# Altium schematic sheet OneKey_Download.SchDoc(OneKey_Download)
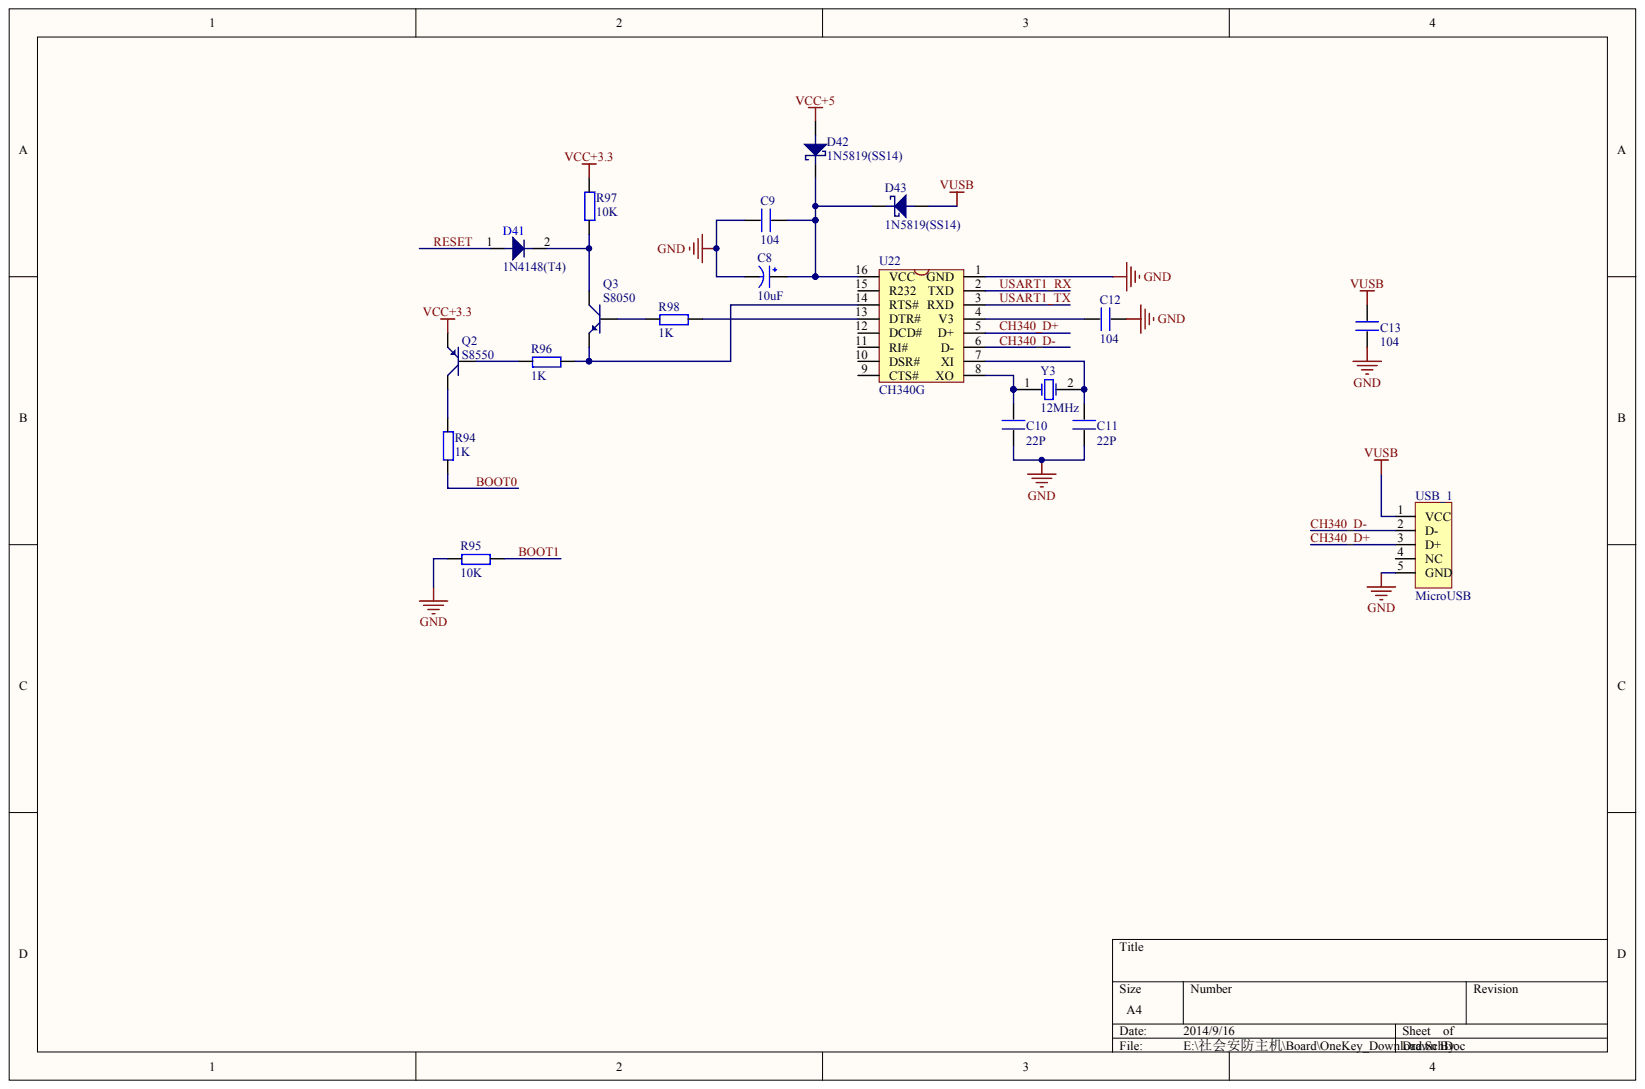
[diagram p0: OneKey_Download.SchDoc]

## Parts
C8  10uF
C9  104
C10  22P
C11  22P
C12  104
C13  104
D41  1N4148(T4)
D42  1N5819(SS14)
D43  1N5819(SS14)
Q2  S8550
Q3  S8050
R94  1K
R95  10K
R96  1K
R97  10K
R98  1K
U22  CH340G
USB_1  MicroUSB
Y3  12MHz

## Nets
GND: C8.2 C9.1 C10.1 C11.1 C12.2 C13.1 R95.1 U22.1(GND) USB_1.5(GND)
VCC+3.3: Q2.1 R97.2
VCC+5: D42.1
VUSB: C13.2 D43.1 USB_1.1(VCC)
BOOT0: R94.1
BOOT1: R95.2
CH340_D+: U22.5(D+) USB_1.3(D+)
CH340_D-: U22.6(D-) USB_1.2(D-)
RESET: D41.1
USART1_RX: U22.2(TXD)
USART1_TX: U22.3(RXD)
NetC8_1: C8.1 C9.2 D42.2 D43.2 U22.16(VCC)
NetC10_2: C10.2 U22.8(XO) Y3.1
NetC11_2: C11.2 U22.7(XI) Y3.2
NetC12_1: C12.1 U22.4(V3)
NetD41_2: D41.2 Q3.3 R97.1
NetQ2_2: Q2.2 R96.1
NetQ2_3: Q2.3 R94.2
NetQ3_1: Q3.1 R96.2 U22.14(RTS#)
NetQ3_2: Q3.2 R98.1
NetR98_2: R98.2 U22.13(DTR#)

Not connected: U22 9(CTS#) 10(DSR#) 11(RI#) 12(DCD#) 15(R232); USB_1 4(NC)

## Library data of the parts
C8-C13 D41-D43 Q2 Q3 R94-R98 U22 USB_1 Y3 = (no symbol name)
  Y3: Published: 8-Jun-2000; LatestRevisionDate: 17-Jul-2002; LatestRevisionNote: Re-released for DXP Platform.; Publisher: Altium Limited
